# Altium schematic sheet Sheet1.SchDoc
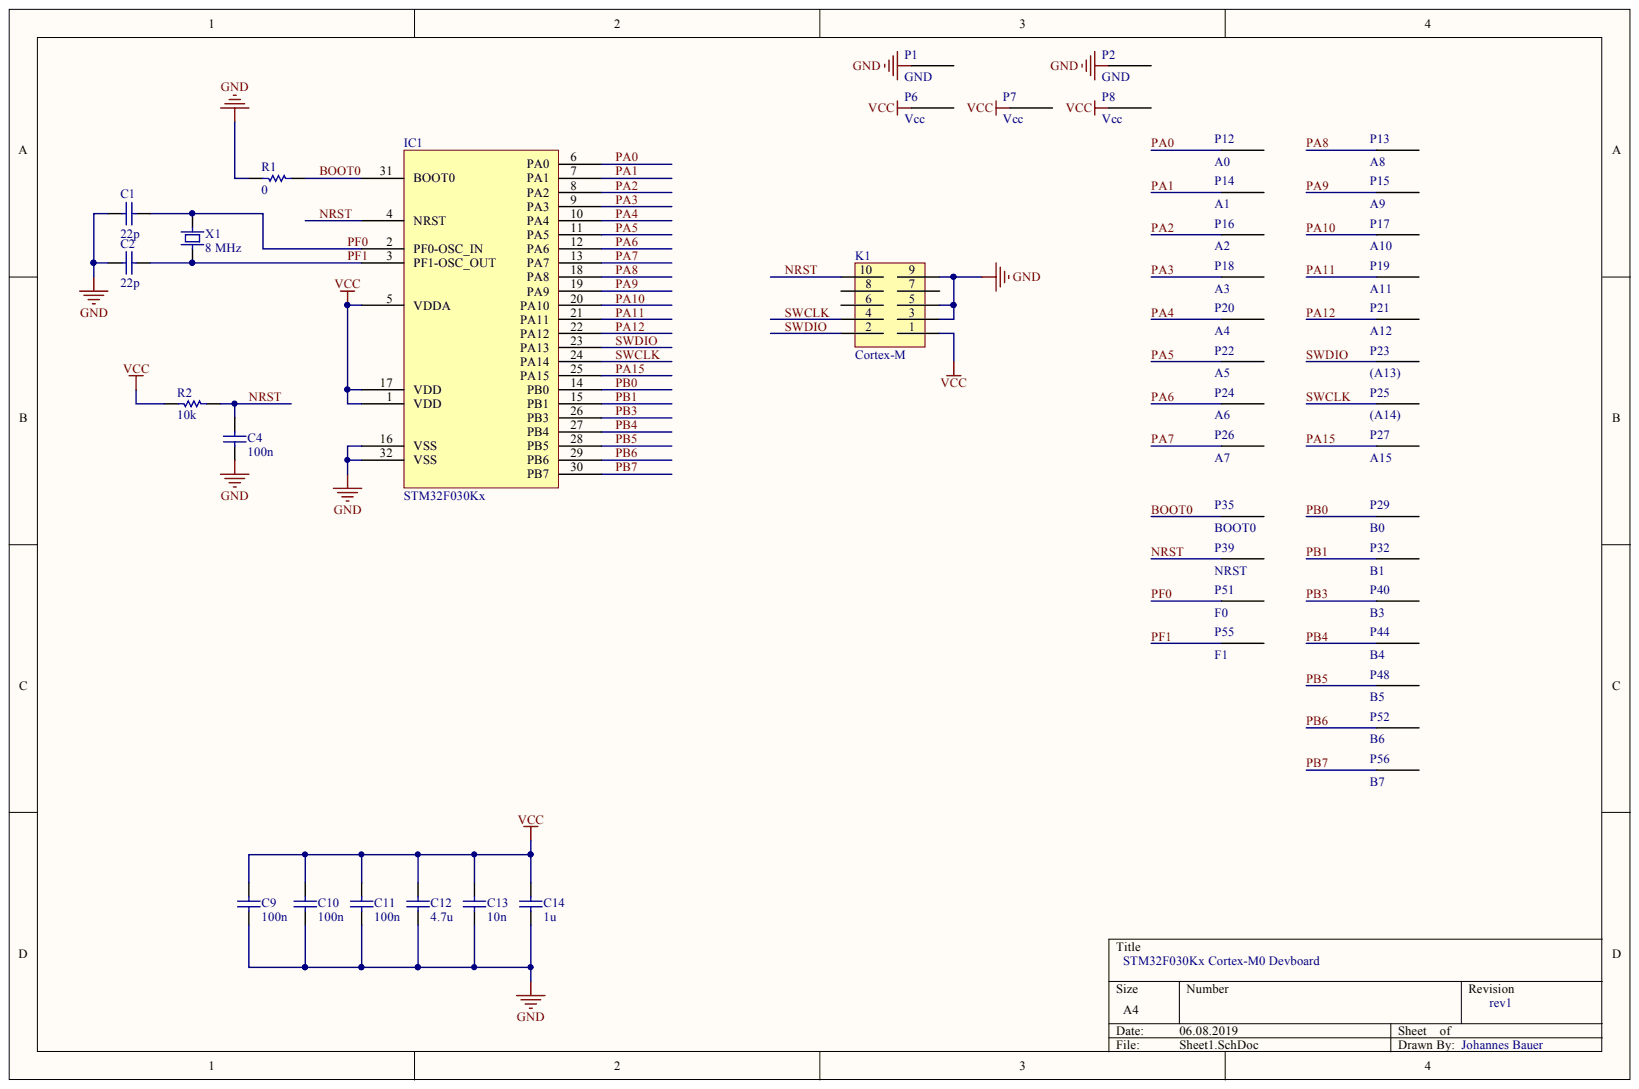
[diagram p0: Sheet1.SchDoc]

## Parts
C1  22p  [CAPC2013X88X50NL20T25]
C2  22p  [CAPC2013X88X50NL20T25]
C4  100n  [CAPC2013X88X50NL20T25]
C9  100n  [CAPC2013X88X50NL20T25]
C10  100n  [CAPC2013X88X50NL20T25]
C11  100n  [CAPC2013X88X50NL20T25]
C12  4.7u  [CAPC2013X88X50NL20T25]
C13  10n  [CAPC2013X88X50NL20T25]
C14  1u  [CAPC2013X88X50NL20T25]
IC1  STM32F030Kx  [STM32F030K6T6]
K1  Cortex-M  [PCB-l6fbhhbmmdzifrvjozvs-1]
P1  GND  [PIN-089]
P2  GND  [PIN-089]
P6  Vcc  [PIN-089]
P7  Vcc  [PIN-089]
P8  Vcc  [PIN-089]
P12  A0  [PIN-089]
P13  A8  [PIN-089]
P14  A1  [PIN-089]
P15  A9  [PIN-089]
P16  A2  [PIN-089]
P17  A10  [PIN-089]
P18  A3  [PIN-089]
P19  A11  [PIN-089]
P20  A4  [PIN-089]
P21  A12  [PIN-089]
P22  A5  [PIN-089]
P23  (A13)  [PIN-089]
P24  A6  [PIN-089]
P25  (A14)  [PIN-089]
P26  A7  [PIN-089]
P27  A15  [PIN-089]
P29  B0  [PIN-089]
P32  B1  [PIN-089]
P35  BOOT0  [PIN-089]
P39  NRST  [PIN-089]
P40  B3  [PIN-089]
P44  B4  [PIN-089]
P48  B5  [PIN-089]
P51  F0  [PIN-089]
P52  B6  [PIN-089]
P55  F1  [PIN-089]
P56  B7  [PIN-089]
R1  0  [RESC2012X07N]
R2  10k  [RESC2012X07N]
X1  8 MHz  [9B-8.000MEEJ-B]

## Nets
GND: C1.2 C2.2 C4.2 C9.2 C10.2 C11.2 C12.2 C13.2 C14.2 IC1.16(VSS) IC1.32(VSS) K1.3 K1.5 K1.9 P1.1 P2.1 R1.1
VCC: C9.1 C10.1 C11.1 C12.1 C13.1 C14.1 IC1.1(VDD) IC1.5(VDDA) IC1.17(VDD) K1.1 P6.1 P7.1 P8.1 R2.1
BOOT0: IC1.31(BOOT0) P35.1 R1.2
NRST: C4.1 IC1.4(NRST) K1.10 P39.1 R2.2
PA0: IC1.6(PA0) P12.1
PA1: IC1.7(PA1) P14.1
PA2: IC1.8(PA2) P16.1
PA3: IC1.9(PA3) P18.1
PA4: IC1.10(PA4) P20.1
PA5: IC1.11(PA5) P22.1
PA6: IC1.12(PA6) P24.1
PA7: IC1.13(PA7) P26.1
PA8: IC1.18(PA8) P13.1
PA9: IC1.19(PA9) P15.1
PA10: IC1.20(PA10) P17.1
PA11: IC1.21(PA11) P19.1
PA12: IC1.22(PA12) P21.1
PA15: IC1.25(PA15) P27.1
PB0: IC1.14(PB0) P29.1
PB1: IC1.15(PB1) P32.1
PB3: IC1.26(PB3) P40.1
PB4: IC1.27(PB4) P44.1
PB5: IC1.28(PB5) P48.1
PB6: IC1.29(PB6) P52.1
PB7: IC1.30(PB7) P56.1
PF0: C1.1 IC1.2(PF0-OSC_IN) P51.1 X1.2
PF1: C2.1 IC1.3(PF1-OSC_OUT) P55.1 X1.1
SWCLK: IC1.24(PA14) K1.4 P25.1
SWDIO: IC1.23(PA13) K1.2 P23.1

Not connected: K1 6 7 8

## Library data of the parts
C1 C2 C4 C9-C14 = CMP-3913301-2: CAPACITOR, 0805, C0G, 50V, 2.2NF; Capacitance: 2.2e-09 F; Capacitance Tolerance: ±5%; Dielectric Characteristic: C0G/NP0; Dielectric Material: Ceramic; Lead-Free Status: Lead Free; Voltage Rating (DC): 50.0 V; Case/Package: 0805; Component Kind: Standard; Library Name: CommunityVault; Mounting Style: Surface Mount; OctopartID: bb4973a362c9648e; Octopart Url: https://octopart.com/c0805c222j5gacauto-kemet-31522022; Packaging: Cut Tape (CT); REACH SVHC Compliance: No SVHC; RoHS: Compliant
IC1 = STM32F030K6T6: 32 Bit Microcontroller, Value Line, ARM Cortex-M0, 48 MHz, 32 KB, 4 KB, 32, LQFP; Clock Speed: 48.0 MHz; Number of Bits: 32; Case/Package: LQFP; Component Kind: Standard; Library Name: Octopart library; Lifecycle Status: Active; Manufacturer Part Number: STM32F030K6T6; Mounting Style: Surface Mount; Number of Pins: 32; OctopartID: 87924f52f9ac5855; Octopart URL: http://octopart.com/stm32f030k6t6-stmicroelectronics-30038872; Packaging: Cut Tape (CT); REACH SVHC Compliance: No SVHC; RoHS: Compliant
K1 = WSL10-4: Wannensteckleiste 10 pin; CustomComponentID: 4svy7yiuz1vlprmntkh4; Component Kind: Standard; Library Name: FavoritesLibrary
P1 P2 P6-P8 P12-P27 P29 P32 P35 P39 P40 P44 P48 P51 P52 P55 P56 = PIN-7: One-pin connector; CustomComponentID: iv9w4hav5xulhqaz2xo1; Component Kind: Standard; Library Name: FavoritesLibrary
R1 R2 = CMP-1041dbfef0d0cf86-1: Res, Thin Film, 7k87, 0.1%, 0.25w, 0805; Manufacturer Part Number: RP73PF2A7K87BTDF; Power Rating: 250 mW; Resistance: 7.87 kΩ; Voltage Rating (DC): 150 V; Case/Package: 0805, 2012; Component Kind: Standard; Library Name: CommunityVault; Lifecycle Status: Not Listed by Manufacturer; Mounting Style: Surface Mount; OctopartID: 1041dbfef0d0cf86; Octopart URL: http://octopart.com/rp73pf2a7k87btdf-te+connectivity-45467127; Packaging: Cut Tape (CT); REACH SVHC Compliance: No SVHC; Resistance Tolerance: ±0.1%; RoHS: Compliant
X1 = 9B-8.000MEEJ-B: Crystal 8MHz +/-10ppm (Tol) +/-20ppm (Stability) 18pF FUND 80Ohm 2-Pin DIP HC-49/S Thru-Hole Bulk; Load Capacitance: 18.0 pF; Component Kind: Standard; Library Name: Octopart library; OctopartID: 9de955fd51fc422e; REACH SVHC Compliance: No SVHC
